# Altium schematic sheet RFID.SchDoc(RFID)
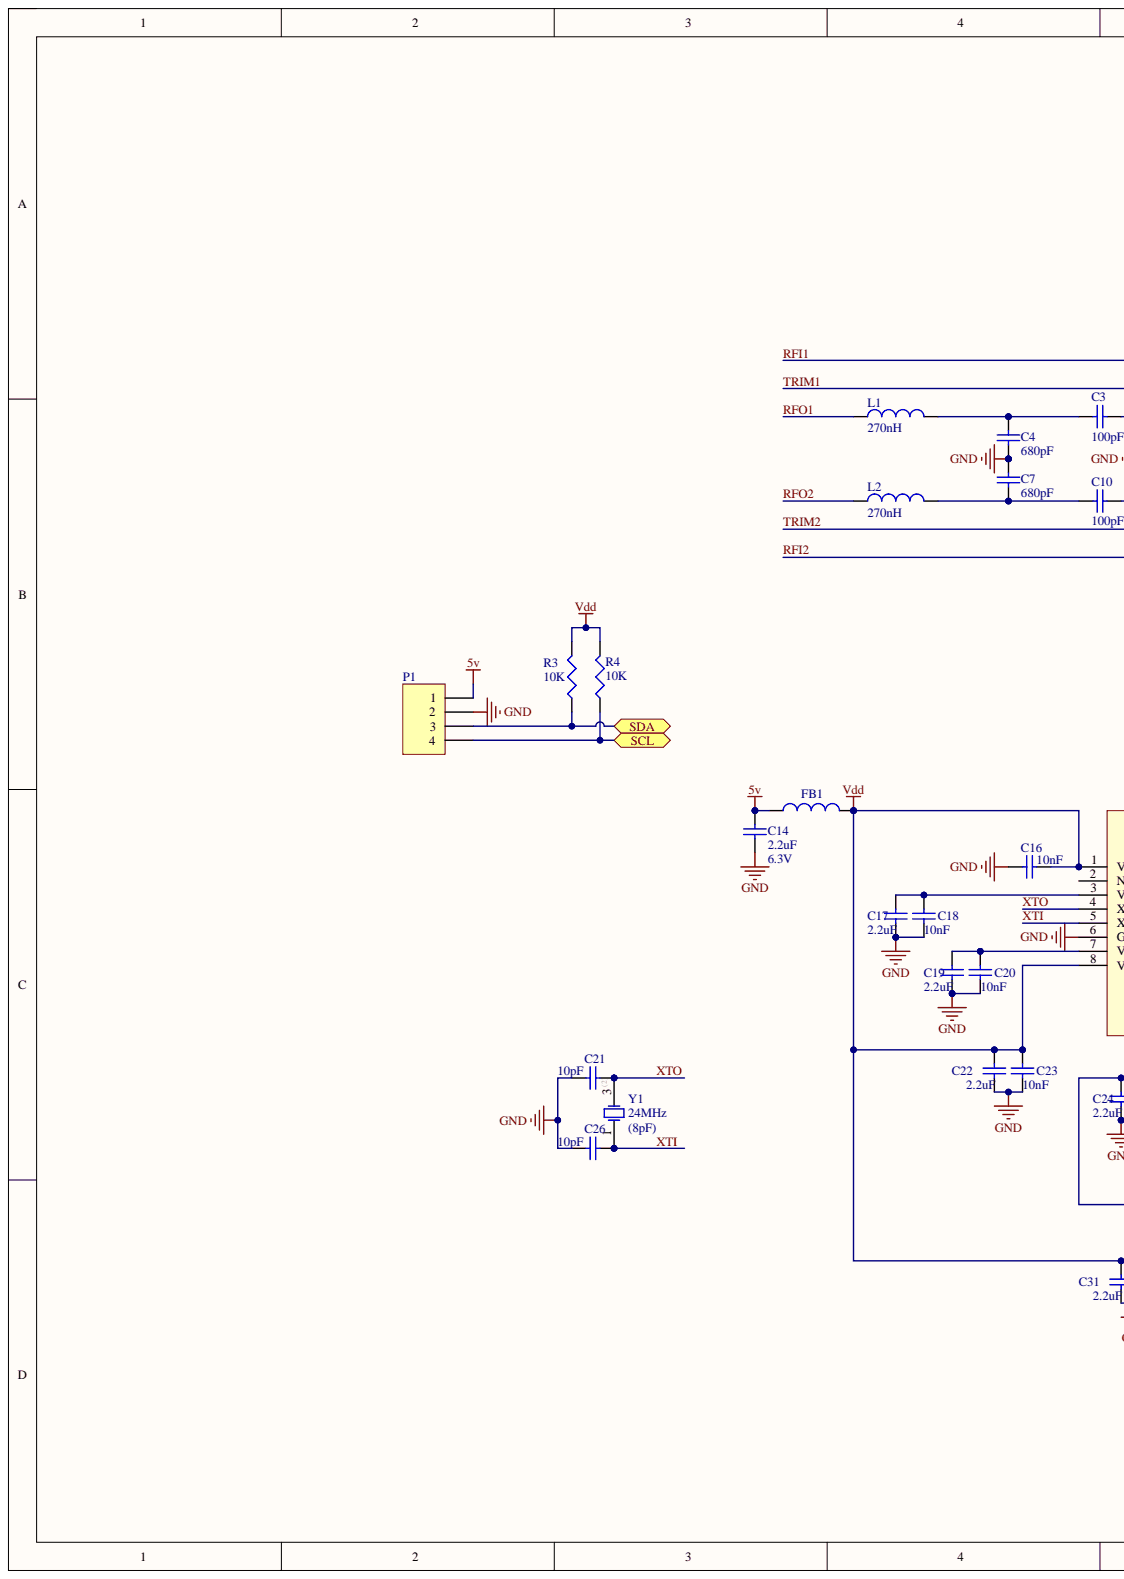
[diagram p0: RFID.SchDoc, left]
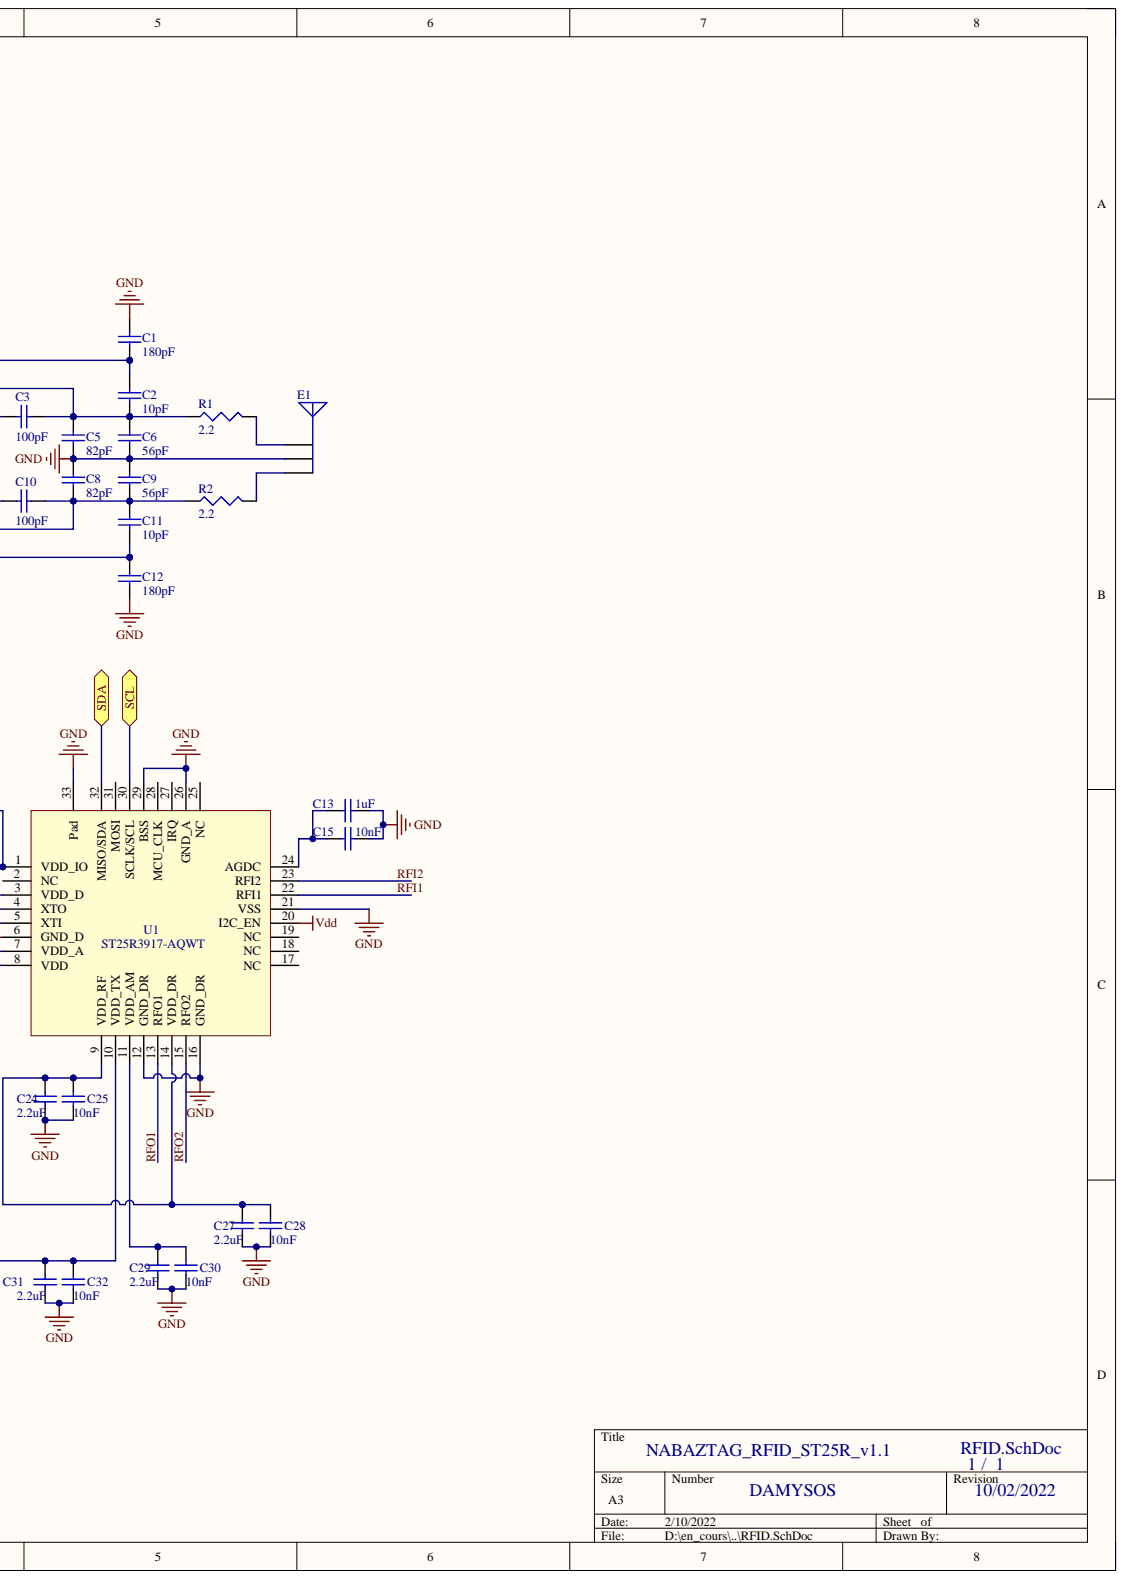
[diagram p1: RFID.SchDoc, right]

## Parts
C1  180pF  [CAP-0603]
C2  10pF  [CAP-0603]
C3  100pF  [CAP-0603]
C4  680pF  [CAP-0603]
C5  82pF  [CAP-0603]
C6  56pF  [CAP-0603]
C7  680pF  [CAP-0603]
C8  82pF  [CAP-0603]
C9  56pF  [CAP-0603]
C10  100pF  [CAP-0603]
C11  10pF  [CAP-0603]
C12  180pF  [CAP-0603]
C13  1uF  [CAP-0603]
C14  2.2uF  Voltage: 6.3V  [CAP-0603]
C15  10nF  [CAP-0603]
C16  10nF  [CAP-0603]
C17  2.2uF  [CAP-0603]
C18  10nF  [CAP-0603]
C19  2.2uF  [CAP-0603]
C20  10nF  [CAP-0603]
C21  10pF  [CAP-0603]
C22  2.2uF  [CAP-0603]
C23  10nF  [CAP-0603]
C24  2.2uF  [CAP-0603]
C25  10nF  [CAP-0603]
C26  10pF  [CAP-0603]
C27  2.2uF  [CAP-0603]
C28  10nF  [CAP-0603]
C29  2.2uF  [CAP-0603]
C30  10nF  [CAP-0603]
C31  2.2uF  [CAP-0603]
C32  10nF  [CAP-0603]
E1  ANTENNA_RFID_ST_ST25R3917_NABAZTAG_1  [ANTENNA_RFID_ST_ST25R3917_NABAZTAG_1]  (value not drawn)
FB1  600R  [CAP-0603]  (value not drawn)
L1  270nH  [CAP-0603]
L2  270nH  [CAP-0603]
P1  Header 4  [HDR1X4]  (value not drawn)
R1  2.2  [RES-0603]
R2  2.2  [RES-0603]
R3  10K  [RES-0603]
R4  10K  [RES-0603]
U1  ST25R3917-AQWT  [RHB32_L_BIS]
Y1  24MHz  Cload: (8pF)  [CRYSTAL_2.0mm_1.6mm_4pts]

## Ports
SCL, SDA

## Nets
5v: C14.2 FB1.2 P1.1
GND: C1.2 C4.1 C5.1 C6.1 C7.2 C8.2 C9.2 C12.1 C13.1 C14.1 C15.1 C16.2 C17.1 C18.1 C19.1 C20.1 C21.1 C22.1 C23.2 C24.1 C25.1 C26.2 C27.1 C28.1 C29.1 C30.1 C31.1 C32.2 E1.2 P1.2 U1.6(GND_D) U1.12(GND_DR) U1.16(GND_DR) U1.21(VSS) U1.26(GND_A) U1.29(BSS) U1.33(Pad)
Vdd: C16.1 C22.2 C23.1 C31.2 C32.1 FB1.1 R3.2 R4.2 U1.1(VDD_IO) U1.8(VDD) U1.10(VDD_TX) U1.20(I2C_EN)
RFI1: C1.1 C2.2 U1.22(RFI1)
RFI2: C11.1 C12.2 U1.23(RFI2)
RFO1: L1.1 U1.13(RFO1)
RFO2: L2.1 U1.15(RFO2)
SCL: P1.4 R4.1 U1.30(SCLK/SCL) ; port SCL
SDA: P1.3 R3.1 U1.32(MISO/SDA) ; port SDA
TRIM1: C2.1 C3.2 C5.2 C6.2 R1.1
TRIM2: C8.1 C9.1 C10.2 C11.2 R2.1
XTI: C26.1 U1.5(XTI) Y1.1
XTO: C21.2 U1.4(XTO) Y1.2
NetC3_1: C3.1 C4.2 L1.2
NetC7_1: C7.1 C10.1 L2.2
NetC13_2: C13.2 C15.2 U1.24(AGDC)
NetC17_2: C17.2 C18.2 U1.3(VDD_D)
NetC19_2: C19.2 C20.2 U1.7(VDD_A)
NetC24_2: C24.2 C25.2 C27.2 C28.2 U1.9(VDD_RF) U1.14(VDD_DR)
NetC29_2: C29.2 C30.2 U1.11(VDD_AM)
NetE1_1: E1.1 R1.2
NetE1_3: E1.3 R2.2

Not connected: U1 2(NC) 17(NC) 18(NC) 19(NC) 25(NC) 27(IRQ) 28(MCU_CLK) 31(MOSI)

## Library data of the parts
C1 C12 = CAP CER 0603 180pF 50V NPO 5%: Ceramic Capacitor Chip; Voltage: 50V; Component Kind: Standard; Signal Integrity: Cap Semi; Simulation: CAP; Value: 180pF; Library Name: Damysos Capacitor.IntLib
C2 C11 C21 C26 = CAP CER 0603 10pF 50V NPO 5%: Ceramic Capacitor Chip; Voltage: 50V; Component Kind: Standard; Signal Integrity: Cap Semi; Simulation: CAP; Value: 10pF; Library Name: Damysos Capacitor.IntLib
C3 C10 = CAP CER 0603 100pF 50V NPO 5%: Ceramic Capacitor Chip; Voltage: 50V; Component Kind: Standard; Signal Integrity: Cap Semi; Simulation: CAP; Value: 100pF; Library Name: Damysos Capacitor.IntLib
C4 C7 = CAP CER 0603 680pF 50V X7R 10%: Ceramic Capacitor Chip; Voltage: 50V; Component Kind: Standard; Signal Integrity: Cap Semi; Simulation: CAP; Value: 680pF; Library Name: Damysos Capacitor.IntLib
C5 C8 = CAP CER 0603 82pF 50V NPO 5%: Ceramic Capacitor Chip; Voltage: 50V; Component Kind: Standard; Signal Integrity: Cap Semi; Simulation: CAP; Value: 82pF; Library Name: Damysos Capacitor.IntLib
C6 C9 = CAP CER 0603 56pF 50V NPO 5%: Ceramic Capacitor Chip; Voltage: 50V; Component Kind: Standard; Signal Integrity: Cap Semi; Simulation: CAP; Value: 56pF; Library Name: Damysos Capacitor.IntLib
C13 = CAP CER 0603 1uF 16V X5R 10%: Ceramic Capacitor Chip; Voltage: 16V; Component Kind: Standard; Package: 0603; Signal Integrity: Cap Semi; Simulation: CAP; Value: 1uF; Library Name: Damysos Capacitor.IntLib
C14 = CAP CER 0603 2.2uF 6.3V X5R 10%: Ceramic Capacitor Chip; Component Kind: Standard; Package: 0603; Signal Integrity: Cap Semi; Simulation: CAP; Value: 2.2uF; Library Name: Damysos Capacitor.IntLib
C15 C16 C18 C20 C23 C25 C28 C30 C32 = CAP CER 0603 10nF 50V X5R 10%: Ceramic Capacitor Chip; Voltage: 50V; Component Kind: Standard; Signal Integrity: Cap Semi; Simulation: CAP; Value: 10nF; Library Name: Damysos Capacitor.IntLib
C17 C19 C22 C24 C27 C29 C31 = CAP CER 0603 2.2uF 6.3V X5R 10%: Ceramic Capacitor Chip; Voltage: 6.3V; Component Kind: Standard; Package: 0603; Signal Integrity: Cap Semi; Simulation: CAP; Value: 2.2uF; Library Name: Damysos Capacitor.IntLib
E1 = ANTENNA_RFID_ST_ST25R3917_NABAZTAG_1: Generic Antenna; Component Kind: Standard; LatestRevisionDate: 17-Jul-2002; LatestRevisionNote: Re-released for DXP Platform.; PackageReference: PIN1; Published: 24-Mar-1999; Library Name: Damysos Misc.IntLib; Publisher: Altium Limited
FB1 = FB 0603 600R@100MHz 1A 0.2R: Ferrite Bead; Manufacturer: Wurth Elektronik; Manufacturer Part Number: 742792651; Component Kind: Standard; Signal Integrity: Inductor; Simulation: INDUCTOR; Value: 600R; Library Name: Damysos Inductor.IntLib
L1 L2 = IND 0603 270nH 2% Q=24: Inductor; Component Kind: Standard; PackageDescription: Chip Inductor; Signal Integrity: Inductor; Simulation: INDUCTOR; Value: 270nH; Library Name: Damysos Inductor.IntLib
P1 = Header 4: Header, 4-Pin; Component Kind: Standard; LatestRevisionDate: 17-Jul-2002; LatestRevisionNote: Re-released for DXP Platform.; Library Name: Miscellaneous Connectors.IntLib; Signal Integrity: Connector; Publisher: Altium Limited
R1 R2 = RES 0603 1% 0.1W 2.2R: Chip Resistor; Component Kind: Standard; PackageDescription: Chip Resistor; Signal Integrity: Res; Simulation: RESISTOR; Value: 2.2; Library Name: Damysos Resistor.IntLib
R3 R4 = RES 0603 1% 0.1W 10K: Chip Resistor; Component Kind: Standard; PackageDescription: Chip Resistor; Signal Integrity: Res; Simulation: RESISTOR; Value: 10K; Library Name: Damysos Resistor.IntLib
U1 = ST25R3917-AQWT: SX1272 - Low Power Integrated UHF Transceiver with Lora technology; Manufacturer: ST Micro; Component Kind: Standard; Manufacturer Part Number: ST25R3917-AQWT; Library Name: Damysos IC.IntLib
Y1 = XTAL 27.12MHz 8pF 30ppm 2.0mm x 1.6mm: Crystal Oscillator; Component Kind: Standard; Simulation: XTAL; Value: 24MHz; Library Name: Damysos Oscillator.IntLib
